# Altium schematic sheet Sheet_connect.SchDoc
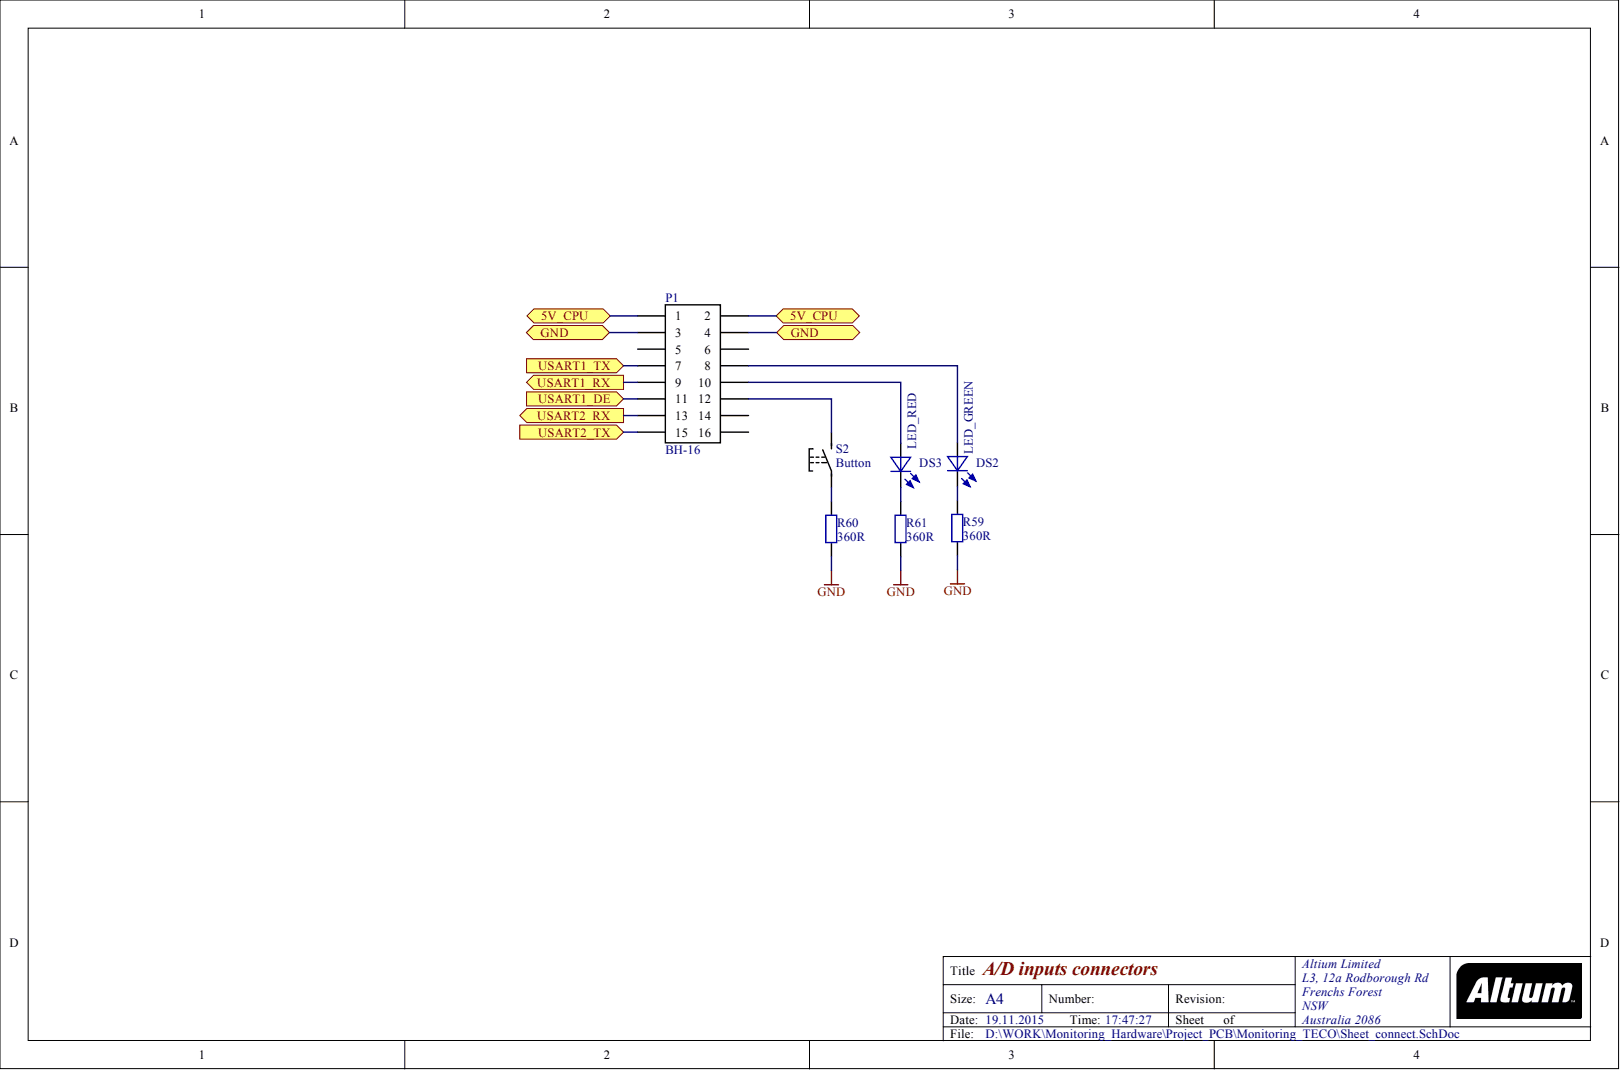
[diagram p0: Sheet_connect.SchDoc]

## Parts
DS2  LED_GREEN  [LED_GREEN]
DS3  LED_RED  [LED_RED]
P1  BH-16  [BH-16]
R59  value 360R  [R_0805]  (hidden comment: Resistor)
R60  value 360R  [R_0805]  (hidden comment: Resistor)
R61  value 360R  [R_0805]  (hidden comment: Resistor)
S2  Button  [Swich_TACTA-68N-F]

## Ports
5V_CPU, GND, USART1_DE, USART1_RX, USART1_TX, USART2_RX, USART2_TX

## Nets
GND: R59.2 R60.2 R61.2
5V_CPU: P1.1 P1.2 ; port 5V_CPU
USART1_DE: P1.11 ; port USART1_DE
USART1_RX: P1.9 ; port USART1_RX
USART1_TX: P1.7 ; port USART1_TX
USART2_RX: P1.13 ; port USART2_RX
USART2_TX: P1.15 ; port USART2_TX
NetDS2_1: DS2.1 P1.8
NetDS2_2: DS2.2 R59.1
NetDS3_1: DS3.1 P1.10
NetDS3_2: DS3.2 R61.1
NetP1_3: P1.3 P1.4 ; port GND
NetP1_12: P1.12 S2.2
NetR60_1: R60.1 S2.1

Not connected: P1 5 6 14 16

## Library data of the parts
DS2 = LED_GREEN: LED; Component Kind: Standard; Library Name: LEDs.SchLib
DS3 = LED_RED: LED; Component Kind: Standard; Library Name: LEDs.SchLib
P1 = BH-16: IDC/BH; Component Kind: Standard; LatestRevisionDate: 17-Jul-2002; LatestRevisionNote: Re-released for DXP Platform.; Library Name: Connect.SchLib; Publisher: Altium Limited
S2 = Swtich: Component Kind: Standard; Description: Swtich; Library Name: Other.SchLib
R59-R61 = Resistor: Component Kind: Standard; Description: Resistor; Library Name: Resistors.SchLib
